# Altium schematic sheet I2C.SchDoc(I2C)
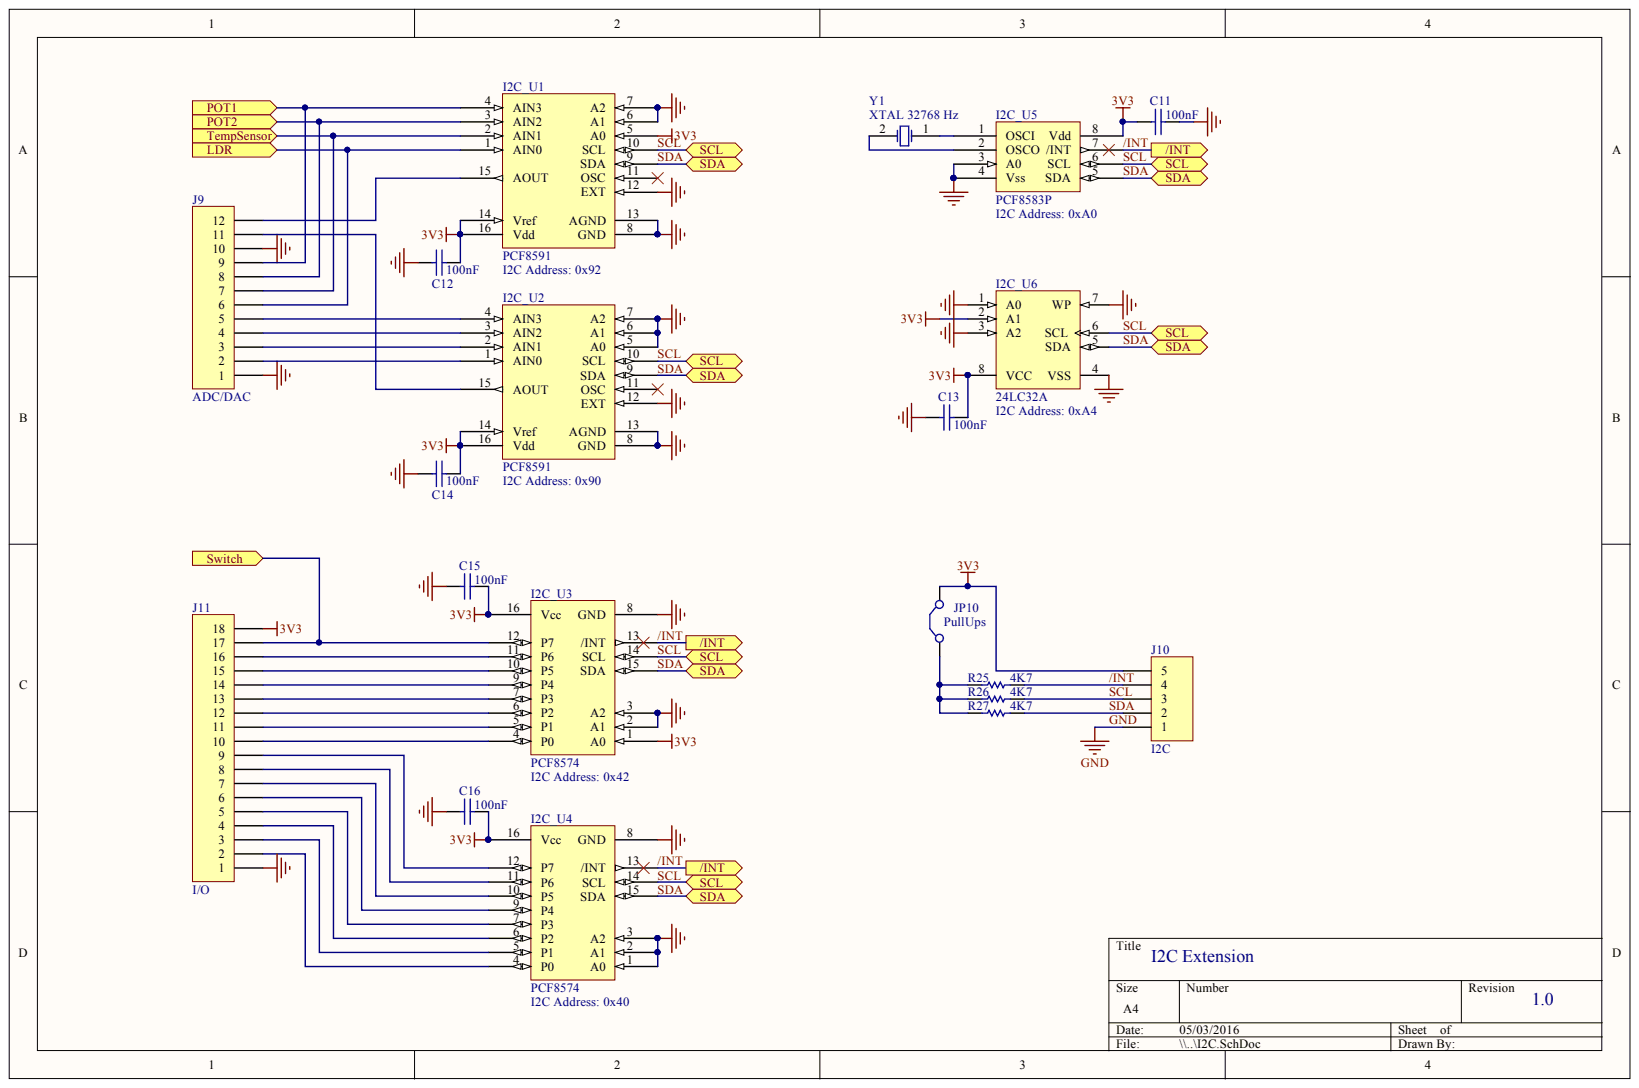
[diagram p0: I2C.SchDoc]

## Parts
C11  100nF  [J1-0603]
C12  100nF  [J1-0603]
C13  100nF  [J1-0603]
C14  100nF  [J1-0603]
C15  100nF  [J1-0603]
C16  100nF  [J1-0603]
I2C_U1  PCF8591  [W016]
I2C_U2  PCF8591  [W016]
I2C_U3  PCF8574  [DIP-16]
I2C_U4  PCF8574  [DIP-16]
I2C_U5  PCF8583P  [DIP-8]
I2C_U6  24LC32A  [DIP-8]
J9  ADC/DAC  [HDR1X12X2]
J10  I2C  [HDR1X5]
J11  I/O  [HDR1X18X2]
JP10  PullUps  [HDR1X2]
R25  4K7  [J1-0603]
R26  4K7  [J1-0603]
R27  4K7  [J1-0603]
Y1  XTAL 32768 Hz  [XTAL MS1V-T1K bis]

## Ports
/INT, LDR, POT1, POT2, SCL, SDA, SWITCH, TEMPSENSOR

## Nets
3V3: C11.2 C12.1 C13.1 C14.1 C15.1 C16.1 I2C_U1.5(A0) I2C_U1.14(Vref) I2C_U1.16(Vdd) I2C_U2.14(Vref) I2C_U2.16(Vdd) I2C_U3.1(A0) I2C_U3.16(Vcc) I2C_U4.16(Vcc) I2C_U5.8(Vdd) I2C_U6.2(A1) I2C_U6.8(VCC) J10.5 J11.18 JP10.2
/INT: I2C_U3.13(/INT) I2C_U4.13(/INT) I2C_U5.7(/INT) J10.4 R25.1 ; port /INT
GND: C11.1 C12.2 C13.2 C14.2 C15.2 C16.2 I2C_U1.6(A1) I2C_U1.7(A2) I2C_U1.8(GND) I2C_U1.12(EXT) I2C_U1.13(AGND) I2C_U2.5(A0) I2C_U2.6(A1) I2C_U2.7(A2) I2C_U2.8(GND) I2C_U2.12(EXT) I2C_U2.13(AGND) I2C_U3.2(A1) I2C_U3.3(A2) I2C_U3.8(GND) I2C_U4.1(A0) I2C_U4.2(A1) I2C_U4.3(A2) I2C_U4.8(GND) I2C_U5.3(A0) I2C_U5.4(Vss) I2C_U6.1(A0) I2C_U6.3(A2) I2C_U6.4(VSS) I2C_U6.7(WP) J9.1 J9.10 J10.1 J11.1
LDR: I2C_U1.1(AIN0) J9.6 ; port LDR
POT1: I2C_U1.4(AIN3) J9.9 ; port POT1
POT2: I2C_U1.3(AIN2) J9.8 ; port POT2
SCL: I2C_U1.10(SCL) I2C_U2.10(SCL) I2C_U3.14(SCL) I2C_U4.14(SCL) I2C_U5.6(SCL) I2C_U6.6(SCL) J10.3 R26.1 ; port SCL
SDA: I2C_U1.9(SDA) I2C_U2.9(SDA) I2C_U3.15(SDA) I2C_U4.15(SDA) I2C_U5.5(SDA) I2C_U6.5(SDA) J10.2 R27.1 ; port SDA
NetI2C_U1_2: I2C_U1.2(AIN1) J9.7 ; port TEMPSENSOR
NetI2C_U1_15: I2C_U1.15(AOUT) J9.12
NetI2C_U2_1: I2C_U2.1(AIN0) J9.2
NetI2C_U2_2: I2C_U2.2(AIN1) J9.3
NetI2C_U2_3: I2C_U2.3(AIN2) J9.4
NetI2C_U2_4: I2C_U2.4(AIN3) J9.5
NetI2C_U2_15: I2C_U2.15(AOUT) J9.11
NetI2C_U3_4: I2C_U3.4(P0) J11.10
NetI2C_U3_5: I2C_U3.5(P1) J11.11
NetI2C_U3_6: I2C_U3.6(P2) J11.12
NetI2C_U3_7: I2C_U3.7(P3) J11.13
NetI2C_U3_9: I2C_U3.9(P4) J11.14
NetI2C_U3_10: I2C_U3.10(P5) J11.15
NetI2C_U3_11: I2C_U3.11(P6) J11.16
NetI2C_U3_12: I2C_U3.12(P7) J11.17 ; port SWITCH
NetI2C_U4_4: I2C_U4.4(P0) J11.2
NetI2C_U4_5: I2C_U4.5(P1) J11.3
NetI2C_U4_6: I2C_U4.6(P2) J11.4
NetI2C_U4_7: I2C_U4.7(P3) J11.5
NetI2C_U4_9: I2C_U4.9(P4) J11.6
NetI2C_U4_10: I2C_U4.10(P5) J11.7
NetI2C_U4_11: I2C_U4.11(P6) J11.8
NetI2C_U4_12: I2C_U4.12(P7) J11.9
NetI2C_U5_1: I2C_U5.1(OSCI) Y1.1
NetI2C_U5_2: I2C_U5.2(OSCO) Y1.2
NetJP10_1: JP10.1 R25.2 R26.2 R27.2

Not connected: I2C_U1 11(OSC); I2C_U2 11(OSC)

## Library data of the parts
C11-C16 = CAP: Capacitor; Component Kind: Standard
I2C_U1 I2C_U2 = PCF8591: 8-bit A/D and D/A converter; Component Kind: Standard; Library Name: Schlib1.SchLib
I2C_U3 I2C_U4 = PCF8574: Remote 8-Bit I/O Expander for I2C Bus; Component Kind: Standard; Library Name: Schlib1.SchLib
I2C_U5 = PCF8583P: I2C Clock and Calendar with 240x8-bit RAM; Component Kind: Standard; Library Name: Schlib1.SchLib
I2C_U6 = 24LC32A-I/P: 32Kbit, 400kHz, 2.5V, I2C Serial EEPROM, 8-Pin PDIP, Industrial Temperature; Code_JEDEC: MS-001; Component Kind: Standard; ComponentLink1Description: Datasheet; ComponentLink1URL: http://ww1.microchip.com/downloads/en/DeviceDoc/21713J.pdf; ComponentLink2Description: Packaging Specification; ComponentLink2URL: http://www.microchip.com/stellent/groups/techpub_sg/documents/packagingspec/en012702.pdf; ComponentLink3Description: Microchip.com Home; ComponentLink3URL: http://www.microchip.com/; DatasheetVersion: revJ, Feb-2009; FirstPublished: 29-Jan-2009; Library Name: Microchip Memory I2C Serial EEPROM.IntLib; PackageDescription: 8-Lead Plastic Dual In-Line (P) - 300 mil Body [PDIP]; PackageReference: PDIP300-P8; PackageVersion: revBB, Aug-2009; Supplier 1: Digi-Key; Supplier 2: Farnell; Supplier 3: Newark; Supplier Part Number 1: 24LC32A-I/P-ND; Supplier Part Number 2: 1331331; Supplier Part Number 3: 96K4078; Publisher: Altium Limited
J9 = Header 12: Header, 12-Pin; Component Kind: Standard; LatestRevisionDate: 17-Jul-2002; LatestRevisionNote: Re-released for DXP Platform.; Library Name: Miscellaneous Connectors.IntLib; Signal Integrity: Connector; Publisher: Altium Limited
J10 = Header 5: Header, 5-Pin; Component Kind: Standard; LatestRevisionDate: 17-Jul-2002; LatestRevisionNote: Re-released for DXP Platform.; Library Name: Miscellaneous Connectors.IntLib; Signal Integrity: Connector; Publisher: Altium Limited
J11 = Header 18: Header, 18-Pin; Component Kind: Standard; LatestRevisionDate: 17-Jul-2002; LatestRevisionNote: Re-released for DXP Platform.; Library Name: Miscellaneous Connectors.IntLib; Signal Integrity: Connector; Publisher: Altium Limited
JP10 = Jumper: Jumper Wire; Component Kind: Standard; LatestRevisionDate: 17-Jul-2002; LatestRevisionNote: Re-released for DXP Platform.; Library Name: Miscellaneous Devices.IntLib; PackageReference: RAD-0.2; Published: 24-Mar-1999; Publisher: Altium Limited
R25-R27 = Res1: Resistor; Component Kind: Standard; LatestRevisionDate: 17-Jul-2002; LatestRevisionNote: Re-released for DXP Platform.; Library Name: Miscellaneous Devices.IntLib; PackageReference: AXIAL-0.3; Published: 8-Jun-2000; Signal Integrity: Res; Simulation: RESISTOR; Value: 4K7; Publisher: Altium Limited
Y1 = XTAL: Crystal Oscillator; Component Kind: Standard; LatestRevisionDate: 17-Jul-2002; LatestRevisionNote: Re-released for DXP Platform.; Library Name: Miscellaneous Devices.IntLib; PackageReference: R38; Published: 8-Jun-2000; Simulation: XTAL; Publisher: Altium Limited
